# Altium schematic sheet Schematics.SchDoc
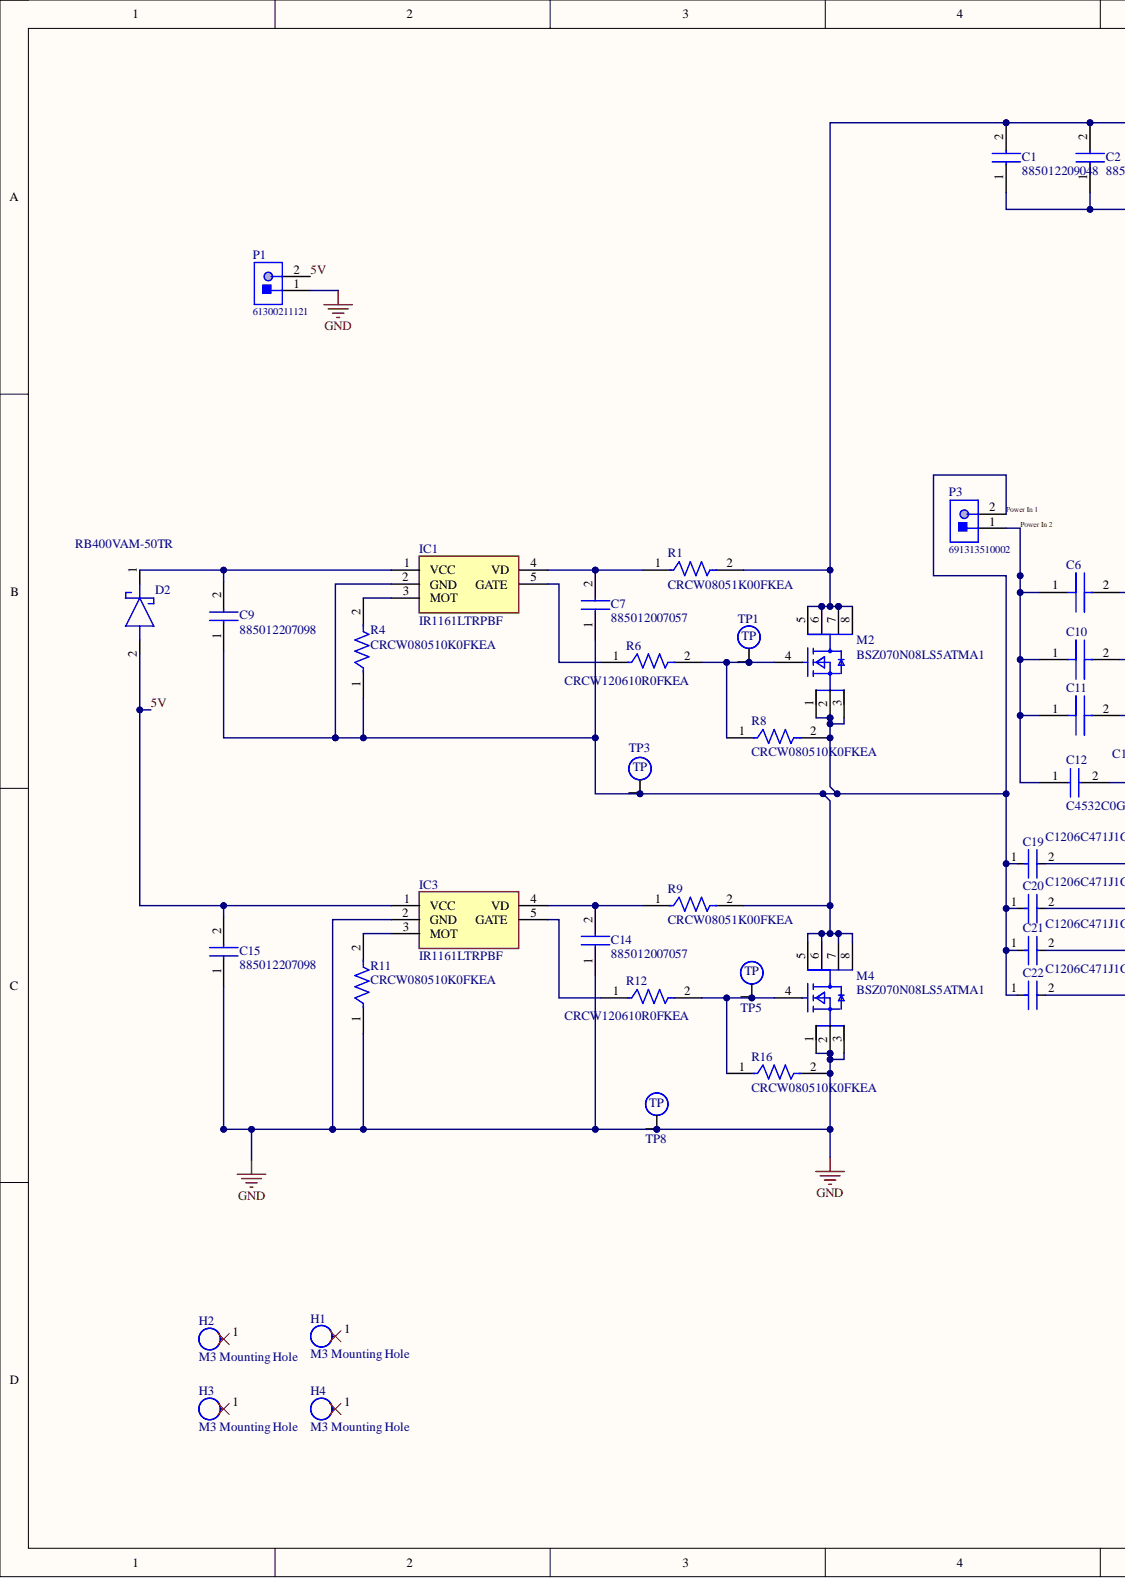
[diagram p0: Schematics.SchDoc, left]
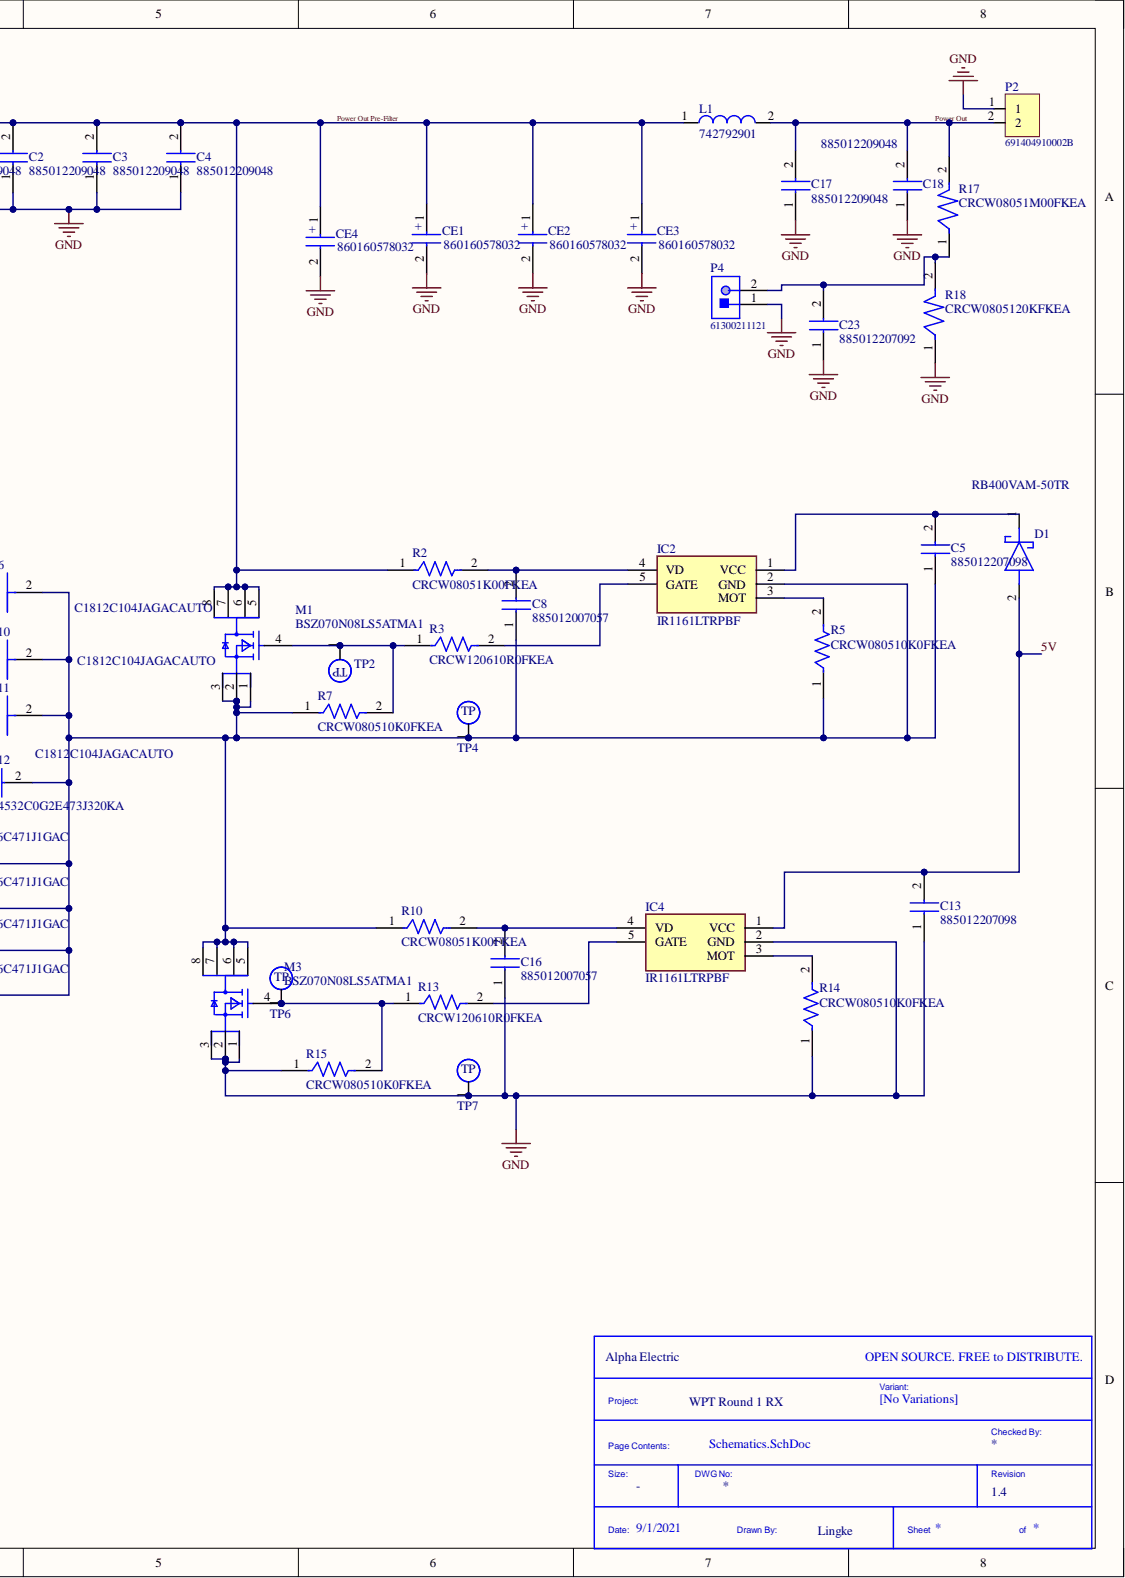
[diagram p1: Schematics.SchDoc, right]

## Parts
C1  885012209048  [CAPC3225X280N]
C2  885012209048  [CAPC3225X280N]
C3  885012209048  [CAPC3225X280N]
C4  885012209048  [CAPC3225X280N]
C5  885012207098  [CAPC2012X90N]
C6  C1812C104JAGACAUTO  [CAPC4532X220N]
C7  885012007057  [CAPC2012X70N]
C8  885012007057  [CAPC2012X70N]
C9  885012207098  [CAPC2012X90N]
C10  C1812C104JAGACAUTO  [CAPC4532X220N]
C11  C1812C104JAGACAUTO  [CAPC4532X220N]
C12  C4532C0G2E473J320KA  [CAPC4532X350N]
C13  885012207098  [CAPC2012X90N]
C14  885012007057  [CAPC2012X70N]
C15  885012207098  [CAPC2012X90N]
C16  885012007057  [CAPC2012X70N]
C17  885012209048  [CAPC3225X280N]
C18  885012209048  [CAPC3225X280N]
C19  C1206C471J1GAC  [FP-C1206C-EB-IPC_A]
C20  C1206C471J1GAC  [FP-C1206C-EB-IPC_A]
C21  C1206C471J1GAC  [FP-C1206C-EB-IPC_A]
C22  C1206C471J1GAC  [FP-C1206C-EB-IPC_A]
C23  885012207092  [CAPC2012X90N]
CE1  860160578032  [CAPPRD500W62D1300H2650]
CE2  860160578032  [CAPPRD500W62D1300H2650]
CE3  860160578032  [CAPPRD500W62D1300H2650]
CE4  860160578032  [CAPPRD500W62D1300H2650]
D1  RB400VAM-50TR  [RB400VAM50TR]
D2  RB400VAM-50TR  [RB400VAM50TR]
H1  M3 Mounting Hole  [M3 Hole]
H2  M3 Mounting Hole  [M3 Hole]
H3  M3 Mounting Hole  [M3 Hole]
H4  M3 Mounting Hole  [M3 Hole]
IC1  IR1161LTRPBF  [SOT95P280X145-5N]
IC2  IR1161LTRPBF  [SOT95P280X145-5N]
IC3  IR1161LTRPBF  [SOT95P280X145-5N]
IC4  IR1161LTRPBF  [SOT95P280X145-5N]
L1  742792901  [WE-PF]
M1  BSZ070N08LS5ATMA1  [BSZ042N06NS]
M2  BSZ070N08LS5ATMA1  [BSZ042N06NS]
M3  BSZ070N08LS5ATMA1  [BSZ042N06NS]
M4  BSZ070N08LS5ATMA1  [BSZ042N06NS]
P1  61300211121  [61300211121]
P2  691404910002B  [691404910002B]
P3  691313510002  [691313510002]
P4  61300211121  [61300211121]
R1  CRCW08051K00FKEA  [RESC2013X50X30NL20T20]
R2  CRCW08051K00FKEA  [RESC2013X50X30NL20T20]
R3  CRCW120610R0FKEA  [FP-CRCW1206-e3-IPC_A]
R4  CRCW080510K0FKEA  [FP-CRCW0805-e3-IPC_A]
R5  CRCW080510K0FKEA  [FP-CRCW0805-e3-IPC_A]
R6  CRCW120610R0FKEA  [FP-CRCW1206-e3-IPC_A]
R7  CRCW080510K0FKEA  [FP-CRCW0805-e3-IPC_A]
R8  CRCW080510K0FKEA  [FP-CRCW0805-e3-IPC_A]
R9  CRCW08051K00FKEA  [RESC2013X50X30NL20T20]
R10  CRCW08051K00FKEA  [RESC2013X50X30NL20T20]
R11  CRCW080510K0FKEA  [FP-CRCW0805-e3-IPC_A]
R12  CRCW120610R0FKEA  [FP-CRCW1206-e3-IPC_A]
R13  CRCW120610R0FKEA  [FP-CRCW1206-e3-IPC_A]
R14  CRCW080510K0FKEA  [FP-CRCW0805-e3-IPC_A]
R15  CRCW080510K0FKEA  [FP-CRCW0805-e3-IPC_A]
R16  CRCW080510K0FKEA  [FP-CRCW0805-e3-IPC_A]
R17  CRCW08051M00FKEA  [FP-CRCW0805-e3-MFG]
R18  CRCW0805120KFKEA  [FP-CRCW0805-e3-MFG]
TP1  TP  [Test Point]  (no BOM)
TP2  TP  [Test Point]  (no BOM)
TP3  TP  [Test Point]  (no BOM)
TP4  TP  [Test Point]  (no BOM)
TP5  TP  [Test Point]  (no BOM)
TP6  TP  [Test Point]  (no BOM)
TP7  TP  [Test Point]  (no BOM)
TP8  TP  [Test Point]  (no BOM)

## Nets
GND: C1.1 C2.1 C3.1 C4.1 C13.1 C14.1 C15.1 C16.1 C17.1 C18.1 C23.1 CE1.2 CE2.2 CE3.2 CE4.2 IC3.2(GND) IC4.2(GND) M3.1 M3.2 M3.3 M4.1 M4.2 M4.3 P1.1 P2.1 P4.1 R11.1 R14.1 R15.1 R16.2 R18.1 TP7.1 TP8.1
5V: C13.2 C15.2 D1.2 D2.2 IC3.1(VCC) IC4.1(VCC) P1.2
Power In 1: C7.1 C9.1 C19.1 C20.1 C21.1 C22.1 IC1.2(GND) M2.1 M2.2 M2.3 M4.5 M4.6 M4.7 M4.8 P3.2 R4.1 R8.2 R9.2 TP3.1
Power In 2: C6.1 C10.1 C11.1 C12.1 P3.1
Power Out: C17.2 C18.2 L1.2 P2.2 R17.2
Power Out Pre-Filter: C1.2 C2.2 C3.2 C4.2 CE1.1 CE2.1 CE3.1 CE4.1 L1.1 M1.5 M1.6 M1.7 M1.8 M2.5 M2.6 M2.7 M2.8 R1.2 R2.1
NetC5_1: C5.1 C6.2 C8.1 C10.2 C11.2 C12.2 C19.2 C20.2 C21.2 C22.2 IC2.2(GND) M1.1 M1.2 M1.3 M3.5 M3.6 M3.7 M3.8 R5.1 R7.1 R10.1 TP4.1
NetC5_2: C5.2 D1.1 IC2.1(VCC)
NetC7_2: C7.2 IC1.4(VD) R1.1
NetC8_2: C8.2 IC2.4(VD) R2.2
NetC9_2: C9.2 D2.1 IC1.1(VCC)
NetC14_2: C14.2 IC3.4(VD) R9.1
NetC16_2: C16.2 IC4.4(VD) R10.2
NetC23_2: C23.2 P4.2 R17.1 R18.2
NetIC1_3: IC1.3(MOT) R4.2
NetIC1_5: IC1.5(GATE) R6.1
NetIC2_3: IC2.3(MOT) R5.2
NetIC2_5: IC2.5(GATE) R3.2
NetIC3_3: IC3.3(MOT) R11.2
NetIC3_5: IC3.5(GATE) R12.1
NetIC4_3: IC4.3(MOT) R14.2
NetIC4_5: IC4.5(GATE) R13.2
NetM1_4: M1.4 R3.1 R7.2 TP2.1
NetM2_4: M2.4 R6.2 R8.1 TP1.1
NetM3_4: M3.4 R13.1 R15.2 TP6.1
NetM4_4: M4.4 R12.2 R16.1 TP5.1

Not connected: H1 1; H2 1; H3 1; H4 1

## Library data of the parts
C1-C4 C17 C18 = 4.7uF: Capacitor  Output Filtering; Manufacturer_Name: Wurth Elektronik; Component Kind: Standard; Datasheet Link: https://katalog.we-online.com/pbs/datasheet/885012209048.pdf; Height: 2.8mm; Library Name: Symbol Library.SchLib; Manufacturer_Part_Number: 885012209048; Supplier 1: Mouser; Supplier Part Number 1: 710-885012209048
C5 C9 C13 C15 = 100nF/50V: Capacitor; Manufacturer_Name: Wurth Elektronik; Component Kind: Standard; Datasheet Link: https://componentsearchengine.com//885012207098.pdf; Height: 0.9mm; Library Name: Symbol Library.SchLib; Manufacturer_Part_Number: 885012207098; Mouser2 Part Number: 710-885012207098; Mouser2 Price/Stock: https://www.mouser.com/Search/Refine.aspx?Keyword=710-885012207098; Supplier 1: Mouser; Supplier Part Number 1: 710-885012207098
C6 C10 C11 = Resonant Tank Cap: 100nF/250V Capacitor 1812; Manufacturer_Name: Kemet; Component Kind: Standard; Datasheet Link: https://api.kemet.com/component-edge/download/datasheet/C1812C104JAGACAUTO.pdf; Height: 2.2mm; Library Name: Symbol Library.SchLib; Manufacturer_Part_Number: C1812C104JAGACAUTO; Supplier 1: Mouser; Supplier Part Number 1: 80-C1812C104JAGAUTO
C7 C8 C14 C16 = 100pF: Capacitor; Manufacturer_Name: Wurth Elektronik; Component Kind: Standard; Datasheet Link: https://katalog.we-online.com/pbs/datasheet/885012007057.pdf; Height: 0.7mm; Library Name: Symbol Library.SchLib; Manufacturer_Part_Number: 885012007057; Supplier 1: Mouser; Supplier Part Number 1: 710-885012007057
C12 = 47nF: Capacitor; Manufacturer_Name: TDK; Component Kind: Standard; Datasheet Link: https://product.tdk.com/info/en/catalog/datasheets/mlcc_commercial_midvoltage_en.pdf; Height: 3.5mm; Library Name: Symbol Library.SchLib; Manufacturer_Part_Number: C4532C0G2E473J320KA; Supplier 1: Mouser; Supplier Part Number 1: 810-C4532C0G2E473J
C19-C22 = 470pF/100V: C1206 470 pF C0G 5.00% 100 V; Manufacturer: KEMET; Automotive Grade: Grade 0; Capacitance: 470 pF; Material: Ceramic; Temperature Coefficient: 15%; Tolerance: 0.05; Value: 470 pF; Voltage Rating: 100 V; Automotive: Yes; Category: Cap; Component Kind: Standard; ComponentLink2Description: Datasheet; ComponentLink2URL: https://content.kemet.com/datasheets/KEM_C1007_X8R_ULTRA_150C_SMD.pdf; Device Class L1: Passive Components; Device Class L2: Capacitors; Device Class L3: Ceramic Capacitors; Height: 0.88mm; Ipc Land Pattern Name: CAPC320160X78; Lead Free: Yes; Library Name: Symbol Library.SchLib; Manufacturer Part Number: C1206C471J1GAC; Mouser Description: Multilayer Ceramic Capacitors MLCC - SMD/SMT 100volts 470pF 5% C0G; Octopart: https://octopart.com/c1206c471j1gac-kemet-1343252; Package: C1206C-EB; RoHS: TRUE; Supplier 1: Mouser; Supplier Part Number 1: 80-C1206C471J1GAC; Temperature: -55°C to +150°C; Temperature Characteristic: C0G; Temperature Range High: +150°C; Temperature Range Low: -55°C
C23 = 10nF 0805 Cap: Capacitor; Manufacturer_Name: Wurth Elektronik; Component Kind: Standard; Datasheet Link: https://katalog.we-online.com/pbs/datasheet/885012207092.pdf; Height: 0.9mm; Library Name: Symbol Library.SchLib; Manufacturer_Part_Number: 885012207092; Supplier 1: Mouser; Supplier Part Number 1: 710-885012207092
CE1-CE4 = 1000uF Output Cap: Capacitor 1000uF Input Filtering; Manufacturer_Name: Wurth Elektronik; Component Kind: Standard; Datasheet Link: https://katalog.we-online.de/pbs/datasheet/860160578032.pdf; Height: 26.5mm; Library Name: Symbol Library.SchLib; Manufacturer_Part_Number: 860160578032; Supplier 1: Mouser; Supplier Part Number 1: 710-860160578032
D1 D2 = Schottky Diode: Manufacturer_Name: ROHM Semiconductor; Component Kind: Standard; Datasheet Link: https://componentsearchengine.com//RB400VAM-50TR.pdf; Description: Schottky Diode; Height: 0.8mm; Library Name: Symbol Library.SchLib; Manufacturer_Part_Number: RB400VAM-50TR; Mouser2 Part Number: 755-RB400VAM-50TR; Mouser2 Price/Stock: https://www.mouser.com/Search/Refine.aspx?Keyword=755-RB400VAM-50TR; Supplier 1: Mouser; Supplier Part Number 1: 755-RB400VAM-50TR
H1-H4 = M3_Mounting_hole: Component Kind: Standard; Library Name: Symbol Library.SchLib
IC1-IC4 = Gate Driver IC: Integrated Circuit; Manufacturer_Name: Infineon; Component Kind: Standard; Height: 1.45mm; Library Name: Symbol Library.SchLib; Manufacturer_Part_Number: IR1161LTRPBF; Supplier 1: Mouser; Supplier Part Number 1: 942-IR1161LTRPBF
L1 = Output Filter Inductor: SMD EMI Suppression Power Ferrite WE-PF, Z = 2900 Ohm; Component Kind: Standard; ComponentLink1Description: Manufacturer Link; ComponentLink1URL: http://www.we-online.com; ComponentLink2Description: Datasheet; ComponentLink2URL: http://www.we-online.de/katalog/media/pdf/742792901.pdf; DatasheetVersion: ver 6, Apr-2007; Impedance: 2900 Ohm; Library Name: Symbol Library.SchLib; PackageDescription: Inductor, 2-Leads, Body 12.0 x 12.0 mm; PackageReference: WE-PF; PackageVersion: 2007; Supplier 1: Mouser; Supplier Part Number 1: 710-742792901
M1-M4 = Mosfet Diode: Integrated Circuit; Manufacturer_Name: Infineon; Component Kind: Standard; Datasheet Link: https://componentsearchengine.com//BSZ070N08LS5ATMA1.pdf; Library Name: Symbol Library.SchLib; Manufacturer_Part_Number: BSZ070N08LS5ATMA1; Mouser2 Part Number: 726-BSZ070N08LS5ATMA; Mouser2 Price/Stock: https://www.mouser.com/Search/Refine.aspx?Keyword=726-BSZ070N08LS5ATMA; Supplier 1: Mouser; Supplier Part Number 1: 726-BSZ070N08LS5ATMA
P1 P4 = 5V Input: THT Vertical Pin Header WR-PHD, Pitch 2.54 mm, Single Row, 2 pins; Manufacturer: Wurth Elektronik; Contact Resistance: 20mOhm; Gender: Male; Pin Number: 2; Pitch: 2.54mm; Rated Current: 3A; Withstanding Voltage: 500VAC; Working Voltage: 250VAC; Component Kind: Standard; Datasheet URL: https://katalog.we-online.de/em/datasheet/6130xx11121.pdf; Library Name: Symbol Library.SchLib; Manufacturer URL: http://www.we-online.de; Mounting Technology: THT; Operating Temperature: -40to105degC; Orientation: Vertical; Package Reference: 61300211121; Packaging: Bag; Part Number: 61300211121; Row Number: Single; Supplier 1: Mouser; Supplier Part Number 1: 710-61300211121; Publisher: WurthElektronik
P2 = Power Output: Serie 4049 B - 7.50mm Screwless Clamp Power Connector WR-TBL, 2 pin; Manufacturer: Wurth Elektronik; Pin Number: 2; Component Kind: Standard; Datasheet URL: http://katalog.we-online.de/em/datasheet/6914049100xxB.pdf; Library Name: Symbol Library.SchLib; Manufacturer URL: http://www.we-online.de; Mounting Technology: THT; Operating Temperature: -40to105degC; Package Reference: 691404910002B; Packaging: Box; Part Number: 691404910002B; Pitch: 7.50mm; Supplier 1: Mouser; Supplier Part Number 1: 710-691404910002B; Publisher: WurthElektronik
P3 = Power Input: Serie 313 - 5.08mm Close Horizontal PCB Header WR-TBL, 2 pin; Manufacturer: Wurth Elektronik; Gender: Male; Pin Number: 2; Component Kind: Standard; Datasheet URL: http://katalog.we-online.de/em/datasheet/6913135100xx.pdf; Library Name: Symbol Library.SchLib; Manufacturer URL: http://www.we-online.de; Mounting Technology: THT; Operating Temperature: -40to105degC; Package Reference: 691313510002; Packaging: Box; Part Number: 691313510002; Pitch: 5.08mm; Supplier 1: Mouser; Supplier Part Number 1: 710-691313510002; Publisher: WurthElektronik
R1 R2 R9 R10 = 1k Ohm: Manufacturer: Vishay Dale; Component Kind: Standard; Library Name: Symbol Library.SchLib; Part Number: CRCW08051K00FKEA; Supplier 1: Mouser; Supplier Part Number 1: 71-CRCW0805-1.0K-E3
R3 R6 R12 R13 = 10 Ohm: RES Thick Film, 10Ω, 1%, 0.25W, 100ppm/°C, 1206; Max Power Dissipation: 250mW; Resistance: 10R; Voltage Rating: 200V; Case Code (Imperial): 1206; Case Code (Metric): 3216; Case/Package: 1206; Component Kind: Standard; Composition: Thick Film; Contact Plating: Tin; Depth: 1.6mm; Features: Automotive AEC-Q200; Height: 0.55mm; Lead Free: Lead Free; Length: 3.2mm; Library Name: Symbol Library.SchLib; Max Operating Temperature: 155°C; Military Standard: Not; Min Operating Temperature: -55°C; Number of Pins: 2; Number of Terminations: 2; Package Quantity: 10; Packaging: Tape and Reel; Power Rating: 250mW; Radiation Hardening: No; Supplier 1: RSComponents; Supplier Part Number 1: 8121782; Temperature Coefficient: 100ppm/°C; Termination: SMD/SMT; Tolerance: 1%; Voltage Rating (DC): 200V; Weight: 0.000353oz; Width: 0.063inch
R4 R5 R7 R8 R11 R14-R16 = 10k Ohm: RES Thick Film, 10kΩ, 1%, 0.125W, 100ppm/°C, 0805; Power Rating: 125mW; Resistance: 10kR; Voltage Rating: 150V; Case Code (Imperial): 0805; Case Code (Metric): 2012; Case/Package: 0805; Component Kind: Standard; Composition: Thick Film; Contact Plating: Tin; Depth: 1.25mm; Height: 0.45mm; Lead Free: Lead Free; Length: 2mm; Library Name: Symbol Library.SchLib; Max Operating Temperature: 155°C; Military Standard: Not; Min Operating Temperature: -55°C; Package Quantity: 5000; Packaging: Tape and Reel; Radiation Hardening: No; Supplier 1: Mouser; Supplier Part Number 1: 71-CRCW0805-10K-E3; Temperature Coefficient: 100ppm/°C; Termination: SMD/SMT; Tolerance: 1%; Voltage Rating (DC): 150V; Weight: 0.000194oz; Width: 1.25mm
R17 = 1M Ohm Resistor: RES Thick Film, 1MΩ, 1%, 0.125W, 100ppm/°C, 0805; Power Rating: 125mW; Resistance: 1MR; Voltage Rating: 150V; Case Code (Imperial): 0805; Case Code (Metric): 2012; Case/Package: 0805; Component Kind: Standard; Composition: Thick Film; Contact Plating: Tin; Depth: 1.25mm; Height: 0.45mm; Lead Free: Lead Free; Length: 2mm; Library Name: Symbol Library.SchLib; Max Operating Temperature: 155°C; Military Standard: Not; Min Operating Temperature: -55°C; Mount: Surface Mount; Number of Pins: 2; Package Quantity: 5000; Packaging: Tape and Reel; Radiation Hardening: No; Supplier 1: Mouser; Supplier Part Number 1: 71-CRCW08051M00FKEAH; Temperature Coefficient: 100ppm/°C; Termination: SMD/SMT; Tolerance: 1%; Voltage Rating (DC): 150V; Weight: 0.000194oz; Width: 1.25mm
R18 = 120Kohm Resistor: RES Thick Film, 120kΩ, 1%, 0.125W, 100ppm/°C, 0805; Max Power Dissipation: 125mW; Resistance: 120kR; Voltage Rating: 150V; Case Code (Imperial): 0805; Case Code (Metric): 2012; Case/Package: 0805; Component Kind: Standard; Composition: Thick Film; Contact Plating: Tin; Depth: 1.25mm; Features: Automotive AEC-Q200; Height: 0.45mm; Lead Free: Lead Free; Length: 2mm; Library Name: Symbol Library.SchLib; Max Operating Temperature: 155°C; Military Standard: Not; Min Operating Temperature: -55°C; Mount: Surface Mount; Number of Terminations: 2; Package Quantity: 10; Packaging: Tape and Reel; Power Rating: 125mW; Radiation Hardening: No; Supplier 1: Mouser; Supplier Part Number 1: 71-CRCW0805120KFKEA; Temperature Coefficient: 100ppm/°C; Termination: SMD/SMT; Tolerance: 1%; Voltage Rating (DC): 150V; Weight: 0.000194oz; Width: 0.049inch
TP1-TP8 = Test Point: Test Point 1mm by 1mm; Library Name: Symbol Library.SchLib
